# Altium schematic sheet Schematic.SchDoc
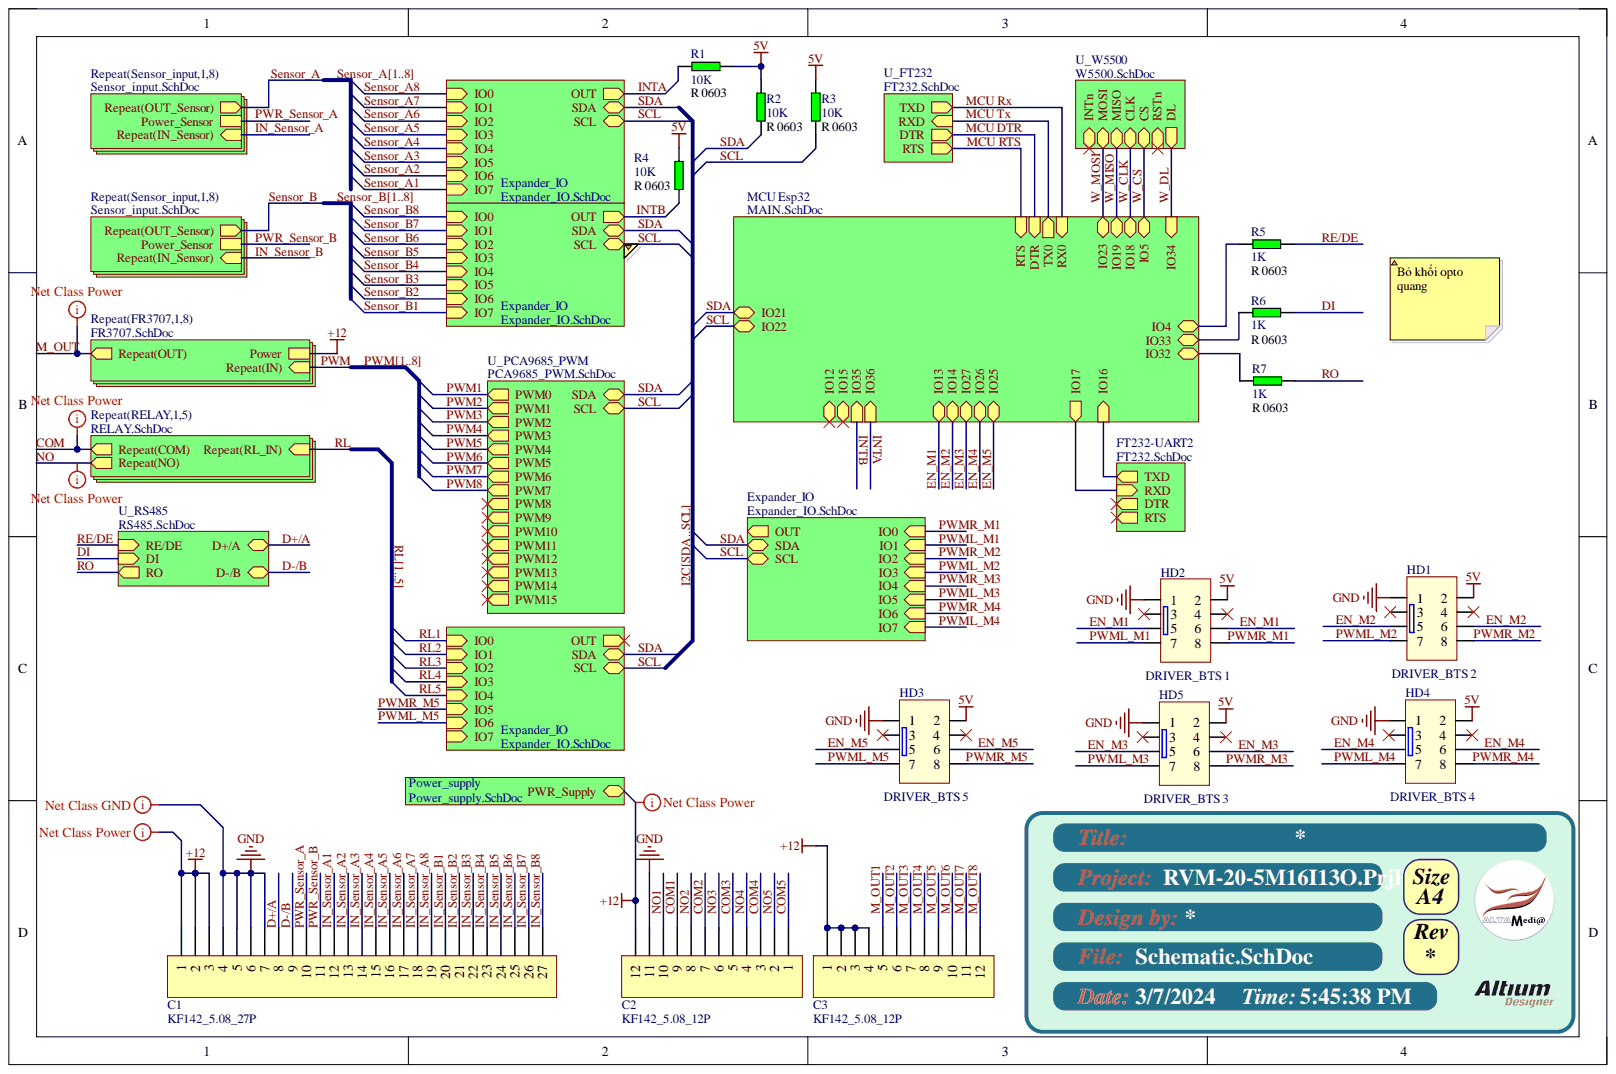
[diagram p0: Schematic.SchDoc]

## Parts
C1  KF142_5.08_27P  [KF142_5.08_27P]
C2  KF142_5.08_12P  [KF142_5.08_12P]
C3  KF142_5.08_12P  [KF142_5.08_12P]
HD1  IDC 4X2  [IDC-8P-2.54mm]  (value not drawn)
HD2  TEXT: DRIVER_BTS 1  [IDC-8P-2.54mm]  (hidden comment: IDC 4X2)
HD3  TEXT: DRIVER_BTS 5  [IDC-8P-2.54mm]  (hidden comment: IDC 4X2)
HD4  TEXT: DRIVER_BTS 4  [IDC-8P-2.54mm]  (hidden comment: IDC 4X2)
HD5  TEXT: DRIVER_BTS 3  [IDC-8P-2.54mm]  (hidden comment: IDC 4X2)
R1  10K  Size: R 0603  [R-0603]
R2  10K  [R-0603]
R3  10K  Size: R 0603  [R-0603]
R4  10K  [R-0603]
R5  1K  Size: R 0603  [R-0603]
R6  1K  Size: R 0603  [R-0603]
R7  1K  Size: R 0603  [R-0603]

## Nets
5V: HD1.2 HD2.2 HD3.2 HD4.2 HD5.2 R1.1 R2.1 R3.1 R4.1
+12: C1.1 C1.2 C1.3 C2.12 C3.1 C3.2 C3.3 C3.4
GND: C1.4 C1.5 C1.6 C1.7 C2.11 HD1.1 HD2.1 HD3.1 HD4.1 HD5.1
COM1: C2.9
COM2: C2.7
COM3: C2.5
COM4: C2.3
COM5: C2.1
D+/A: C1.8
D-/B: C1.9
DI: R6.2
EN_M1: HD2.5 HD2.6
EN_M2: HD1.5 HD1.6
EN_M3: HD5.5 HD5.6
EN_M4: HD4.5 HD4.6
EN_M5: HD3.5 HD3.6
IN_Sensor_A1: C1.12
IN_Sensor_A2: C1.13
IN_Sensor_A3: C1.14
IN_Sensor_A4: C1.15
IN_Sensor_A5: C1.16
IN_Sensor_A6: C1.17
IN_Sensor_A7: C1.18
IN_Sensor_A8: C1.19
IN_Sensor_B1: C1.20
IN_Sensor_B2: C1.21
IN_Sensor_B3: C1.22
IN_Sensor_B4: C1.23
IN_Sensor_B5: C1.24
IN_Sensor_B6: C1.25
IN_Sensor_B7: C1.26
IN_Sensor_B8: C1.27
INTA: R1.2
INTB: R4.2
M_OUT1: C3.5
M_OUT2: C3.6
M_OUT3: C3.7
M_OUT4: C3.8
M_OUT5: C3.9
M_OUT6: C3.10
M_OUT7: C3.11
M_OUT8: C3.12
NO1: C2.10
NO2: C2.8
NO3: C2.6
NO4: C2.4
NO5: C2.2
PWML_M1: HD2.7
PWML_M2: HD1.7
PWML_M3: HD5.7
PWML_M4: HD4.7
PWML_M5: HD3.7
PWMR_M1: HD2.8
PWMR_M2: HD1.8
PWMR_M3: HD5.8
PWMR_M4: HD4.8
PWMR_M5: HD3.8
PWR_Sensor_A: C1.10
PWR_Sensor_B: C1.11
RE/DE: R5.2
RO: R7.1
SCL: R3.2
SDA: R2.2
NetHD1_3: HD1.3
NetHD1_4: HD1.4
NetHD2_3: HD2.3
NetHD2_4: HD2.4
NetHD3_3: HD3.3
NetHD3_4: HD3.4
NetHD4_3: HD4.3
NetHD4_4: HD4.4
NetHD5_3: HD5.3
NetHD5_4: HD5.4
NetR5_1: R5.1
NetR6_1: R6.1
NetR7_2: R7.2
DTR:
I2C[SDA..SCL]:  [also labelled I2C]
INTn:
IO7:
IO12:
IO15:
IO16:
IO17:
MCU DTR:
MCU RTS:
MCU Rx:
MCU Tx:
OUT:
PWM1:  [also labelled PWM]
PWM2:  [also labelled PWM]
PWM3:  [also labelled PWM]
PWM4:  [also labelled PWM]
PWM5:  [also labelled PWM]
PWM6:  [also labelled PWM]
PWM7:  [also labelled PWM]
PWM8:
PWM8:  [also labelled PWM]
PWM9:
PWM10:
PWM11:
PWM12:
PWM13:
PWM14:
PWM15:
RL1:  [also labelled RL]
RL2:  [also labelled RL]
RL3:  [also labelled RL]
RL4:  [also labelled RL]
RL5:  [also labelled RL]
RSTn:
RTS:
Sensor_A1:  [also labelled Sensor_A]
Sensor_A2:  [also labelled Sensor_A]
Sensor_A3:  [also labelled Sensor_A]
Sensor_A4:  [also labelled Sensor_A]
Sensor_A5:  [also labelled Sensor_A]
Sensor_A6:  [also labelled Sensor_A]
Sensor_A7:  [also labelled Sensor_A]
Sensor_A8:  [also labelled Sensor_A]
Sensor_B1:  [also labelled Sensor_B]
Sensor_B2:  [also labelled Sensor_B]
Sensor_B3:  [also labelled Sensor_B]
Sensor_B4:  [also labelled Sensor_B]
Sensor_B5:  [also labelled Sensor_B]
Sensor_B6:  [also labelled Sensor_B]
Sensor_B7:  [also labelled Sensor_B]
Sensor_B8:  [also labelled Sensor_B]
W_CLK:
W_CS:
W_DL:
W_MISO:
W_MOSI:

## Library data of the parts
C1 = JP27: WR-PHD 2.54 mm Angled Socket Header; Manufacturer: W¨¹rth Elektronik eiSos GmbH; partNo: 613025143121; ComponentLink1 Description: Manufacturer; ComponentLink2 Description: Datasheet; Component Kind: Standard; ComponentLink1URL: http://www.we-online.com; ComponentLink2URL: http://katalog.we-online.de/em/datasheet/6130xx143121.pdf; Created Date: 29 March 2013; Library Name: My Library.SchLib; Value: KF142_5.08_27P; Created by: Karrer Zheng
C2 C3 = JP12: WR-PHD 2.54 mm Angled Socket Header; Manufacturer: W¨¹rth Elektronik eiSos GmbH; partNo: 613012143121; ComponentLink1 Description: Manufacturer; ComponentLink2 Description: Datasheet; Component Kind: Standard; ComponentLink1URL: http://www.we-online.com; ComponentLink2URL: http://katalog.we-online.de/em/datasheet/6130xx143121.pdf; Created Date: 29 March 2013; Library Name: My Library.SchLib; Value: KF142_5.08_12P; Created by: Karrer Zheng
HD1 = IDC 4X2: TEXT: DRIVER_BTS 2; Component Kind: Standard; Library Name: My Library.SchLib; Value: IDC 4X2
R1-R7 = RES: SAISO: 5%; Size2: R 0805; Component Kind: Standard; Library Name: Schlib.SchLib
  R1 R3: Value: 10K
  R2 R4: Size: R 0603; Value: 10K
  R5-R7: Value: 1K
HD2-HD5 = IDC 4X2: Component Kind: Standard; Library Name: My Library.SchLib; Value: IDC 4X2
